# Altium schematic sheet isoSPI_Interface.SchDoc
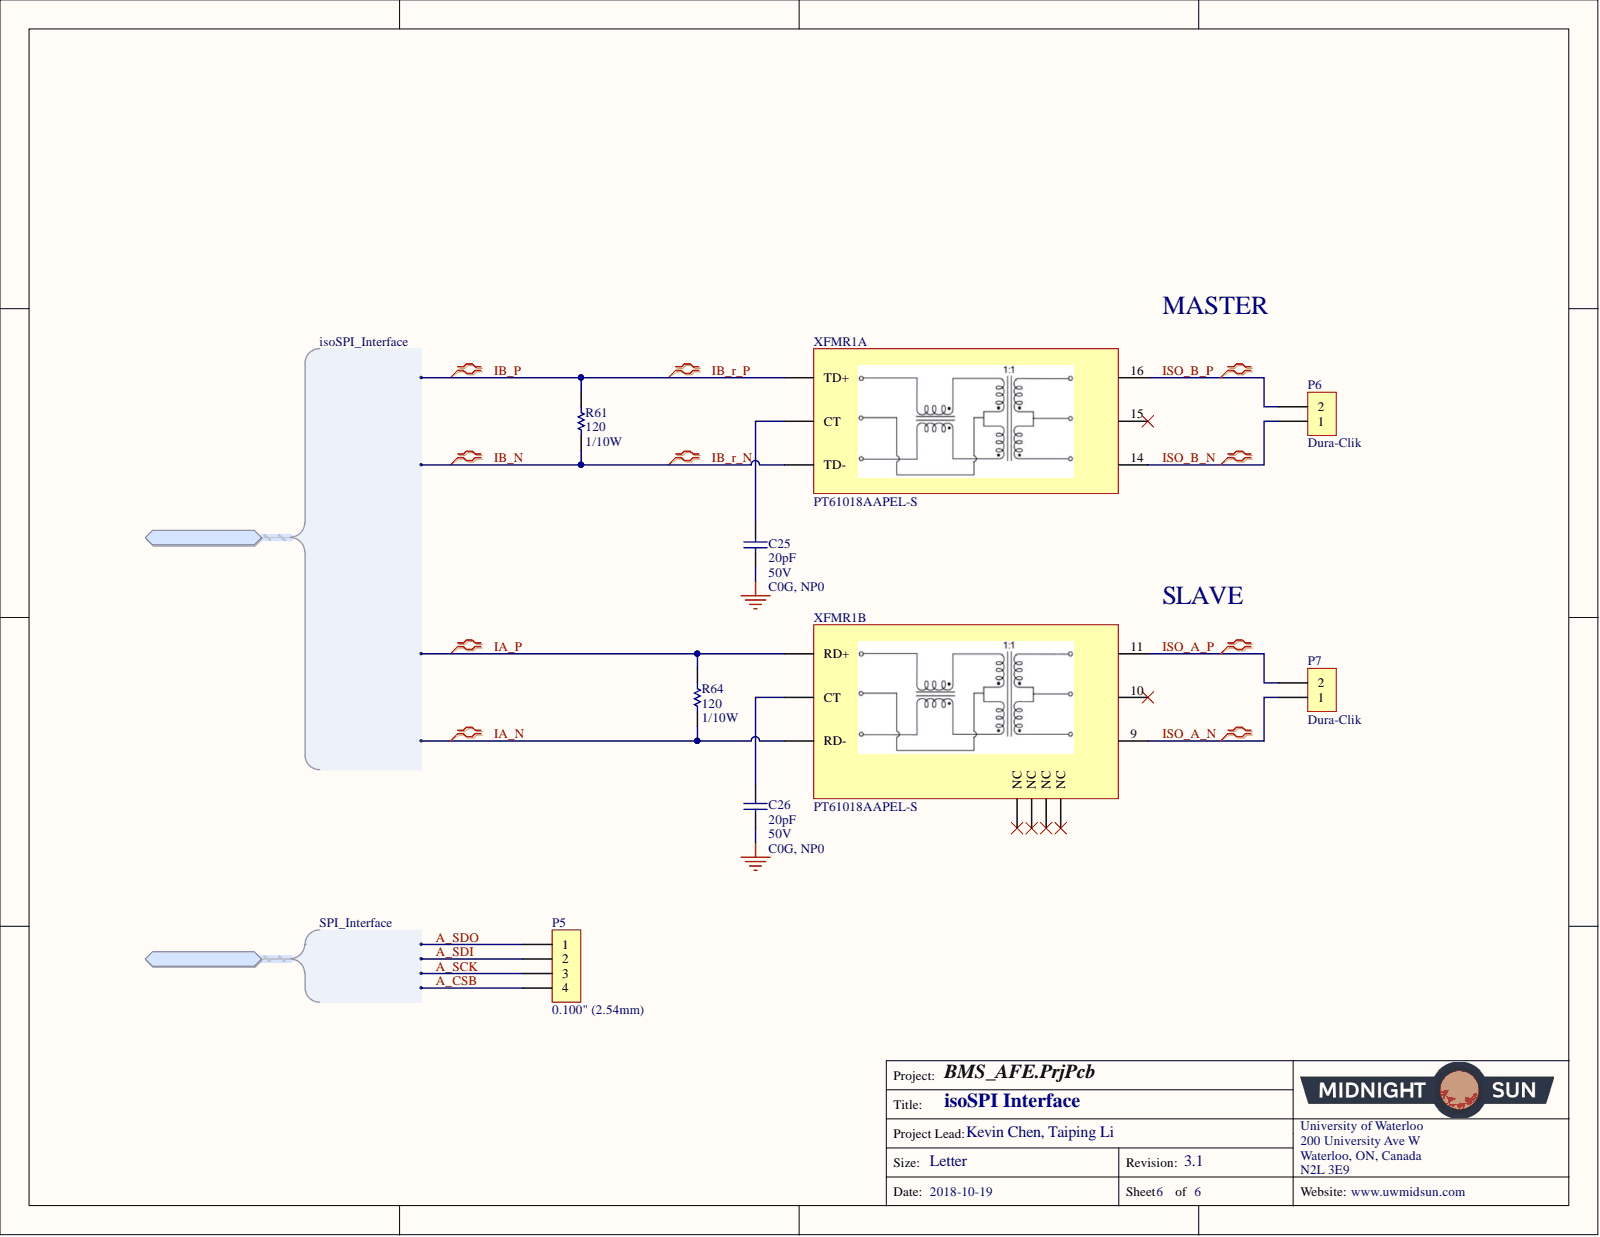
[diagram p0: isoSPI_Interface.SchDoc]

## Parts
C25  Capacitance: 20pF  Voltage: 50V  [CAP, 0603]  (hidden comment: CAP CER 20PF 50V ±5% C0G/NP0 0603)
C26  Capacitance: 20pF  Voltage: 50V  [CAP, 0603]  (hidden comment: CAP CER 20PF 50V ±5% C0G/NP0 0603)
P5  Insulation Height: 0.100" (2.54mm)  [CONN 4POS 0.100"]  (hidden comment: CONN 4POS HEADR MALE 0.1")
P6  Dura-Clik  [CONN, 2POS Dura-Click Vertical]
P7  Dura-Clik  [CONN, 2POS Dura-Click Vertical]
R61  Power: 1/10W  [RES, 0603]  (hidden comment: RES 120 OHM 1% 1/10W 0603)
R64  Power: 1/10W  [RES, 0603]  (hidden comment: RES 120 OHM 1% 1/10W 0603)
XFMR1  MFG_PN: PT61018AAPEL-S  [IC, PULSE XFMR 1CT:1CT 350UH SMD]  (hidden comment: IC PULSE XFMR 1CT:1CT 350UH SMD)  (parts A,B)

## Ports
isoSPI_Interface, isoSPI_Interface.IA_N, isoSPI_Interface.IA_P, isoSPI_Interface.IB_N, isoSPI_Interface.IB_P, SPI_Interface, SPI_Interface.A_CSB, SPI_Interface.A_SCK, SPI_Interface.A_SDI, SPI_Interface.A_SDO

## Nets
GND: C25.2 C26.2
A_CSB: P5.4 ; port SPI_Interface
A_SCK: P5.3 ; port SPI_Interface
A_SDI: P5.2 ; port SPI_Interface
A_SDO: P5.1 ; port SPI_Interface
IA_N: R64.2 XFMR1.8(RD-) ; port isoSPI_Interface
IA_P: R64.1 XFMR1.6(RD+) ; port isoSPI_Interface
IB_N: R61.2 XFMR1.3(TD-) ; port isoSPI_Interface
IB_P: R61.1 XFMR1.1(TD+) ; port isoSPI_Interface
ISO_A_N: P7.1 XFMR1.9
ISO_A_P: P7.2 XFMR1.11
ISO_B_N: P6.1 XFMR1.14
ISO_B_P: P6.2 XFMR1.16
NetC25_1: C25.1 XFMR1.2(CT)
NetC26_1: C26.1 XFMR1.7(CT)

Not connected: XFMR1 4(NC) 5(NC) 10 12(NC) 13(NC) 15

## Library data of the parts
C25 C26 = CAP CER 20PF 50V ±5% C0G/NP0 0603: 20pF ±5% 50V Ceramic Capacitor C0G, NP0 0603 (1608 Metric); Manufacturer 1: Murata Electronics North America; Manufacturer Part Number 1: GRM1885C1H200JA01D; Component Kind: Standard; ComponentLink1Description: http://www.murata.com/~/media/webrenewal/support/library/catalog/products/capacitor/mlcc/c02e.ashx; ComponentLink1URL: http://www.murata.com/~/media/webrenewal/support/library/catalog/products/capacitor/mlcc/c02e.ashx; Height - Seated (Max): -; Lead Spacing: -; Lead Style: -; Mounting Type: Surface Mount, MLCC; Operating Temperature: -55°C ~ 125°C; Package / Case: 0603 (1608 Metric); Packaging: Cut Tape (CT); Part Status: Active; Ratings: -; Size / Dimension: 0.063" L x 0.031" W (1.60mm x 0.80mm); Supplier 1: Digi-Key; Supplier Part Number 1: 490-1410-1-ND; Temperature Coefficient: C0G, NP0; Thickness (Max): 0.035" (0.90mm); Tolerance: ±5%; Library Name: Schematic Diagrams.SchLib
P5 = CONN 4POS HEADR MALE 0.1": 4 Positions Header Connector 0.100" (2.54mm) Through Hole Gold; Manufacturer 1: Wurth Electronics Inc.; Manufacturer Part Number 1: 61300411121; Contact Length - Mating: 0.236" (6.00mm); Contact Length - Post: 0.118" (3.00mm); Current Rating: 3A; Material Flammability Rating: UL94 V-0; Number of Positions: 4; Number of Positions Loaded: All; Overall Contact Length: 0.454" (11.54mm); Shrouding: Unshrouded; Style: Board to Board or Cable; Voltage: 250V; Component Kind: Standard; ComponentLink1Description: http://katalog.we-online.de/em/datasheet/6130xx11121.pdf; ComponentLink1URL: http://katalog.we-online.de/em/datasheet/6130xx11121.pdf; Connector Type: Header; Contact Finish - Mating: Gold; Contact Finish - Post: -; Contact Finish Thickness - Mating: -; Contact Finish Thickness - Post: -; Contact Material: Copper Alloy; Contact Shape: Square; Contact Type: Male Pin; Fastening Type: -; Ingress Protection: -; Insulation Color: Black; Insulation Material: Polybutylene Terephthalate (PBT); Mated Stacking Heights: -; Mounting Type: Through Hole; Number of Rows: 1; Operating Temperature: -40°C ~ 125°C; Packaging: Bulk; Part Status: Active; Pitch - Mating: 0.100" (2.54mm); Row Spacing - Mating: -; Supplier 1: Digi-Key; Supplier Part Number 1: 732-5317-ND; Termination: Solder; Library Name: Schematic Diagrams.SchLib
P6 P7 = CONN 2POS DURA-CLIK 0.079" VERT: 2 Positions Header, Shrouded Connector 0.079" (2.00mm) Surface Mount, Vertical Tin; Manufacturer: Molex, LLC; Manufacturer Part Number: 5600200220; Mated Stacking Heights: 9.3mm; Number of Positions: 2; Number of Positions Loaded: All; Shrouding: Shrouded - 4 Wall; Style: Board to Cable/Wire; Applications: Automotive, Industrial; Category: Connectors, Interconnects; Component Kind: Standard; ComponentLink1Description: http://www.molex.com/pdm_docs/sd/5600200220_sd.pdf; ComponentLink1URL: http://www.molex.com/pdm_docs/sd/5600200220_sd.pdf; Connector Type: Header; Contact Finish - Mating: Tin; Contact Finish - Post: Tin; Contact Finish Thickness - Mating: -; Contact Finish Thickness - Post: -; Contact Length - Mating: -; Contact Length - Post: -; Contact Material: Copper Alloy; Contact Shape: Square; Contact Type: Male Pin; Current Rating: -; Fastening Type: Latch Lock; Features: Solder Retention; Ingress Protection: -; Insulation Color: Natural; Insulation Height: 0.315" (8.00mm); Insulation Material: Polyamide (PA), Nylon; Material Flammability Rating: -; Mounting Type: Surface Mount; Number of Rows: 1; Operating Temperature: -; Overall Contact Length: -; Packaging: Cut Tape (CT); Part Status: Active; Pitch - Mating: 0.079" (2.00mm); Pricing 1: 1=1.16, 10=1.012, 25=0.952, 50=0.9122, 100=0.8727, 250=0.79336 (CAD); RoHS: RoHS Compliant; Row Spacing - Mating: -; Stock 1: 11912; Supplier 1: Digi-Key; Supplier Part Number 1: WM10862CT-ND; Termination: Solder; Voltage Rating: -; Library Name: Schematic Diagrams.SchLib
R61 R64 = RES 120 OHM 1% 1/10W 0603: RES SMD 120 OHM 1% 1/10W 0603; Manufacturer 1: Yageo; Manufacturer Part Number 1: RC0603FR-07120RL; Resistance: 120; Temperature Coefficient: ±100ppm/°C; Component Kind: Standard; ComponentLink1Description: http://www.yageo.com/documents/recent/PYu-RC_Group_51_RoHS_L_7.pdf; ComponentLink1URL: http://www.yageo.com/documents/recent/PYu-RC_Group_51_RoHS_L_7.pdf; Composition: Thick Film; Failure Rate: -; Height - Seated (Max): 0.022" (0.55mm); Operating Temperature: -55°C ~ 155°C; Package / Case: 0603 (1608 Metric); Packaging: Cut Tape (CT); Part Status: Active; Size / Dimension: 0.063" L x 0.031" W (1.60mm x 0.80mm); Supplier 1: Digi-Key; Supplier Device Package: 0603; Supplier Part Number 1: 311-120HRCT-ND; Tolerance: ±1%; Library Name: Schematic Diagrams.SchLib
XFMR1 = IC PULSE XFMR 1CT:1CT 350UH SMD: PULSE XFMR 1CT:1CT 350UH SMD; Manufacturer: Bourns Inc.; Isolation Voltage: 1.5kVrms; Component Kind: Standard; Inductance: 350uH; Supplier: Digikey; Supplier 1: Digi-Key; Supplier_PN: PT61018AAPEL-SCT-ND; Supplier Part Number 1: PT61018AAPEL-SCT-ND; Library Name: Schematic Diagrams.SchLib
